# Altium schematic sheet Control.SchDoc(Control)
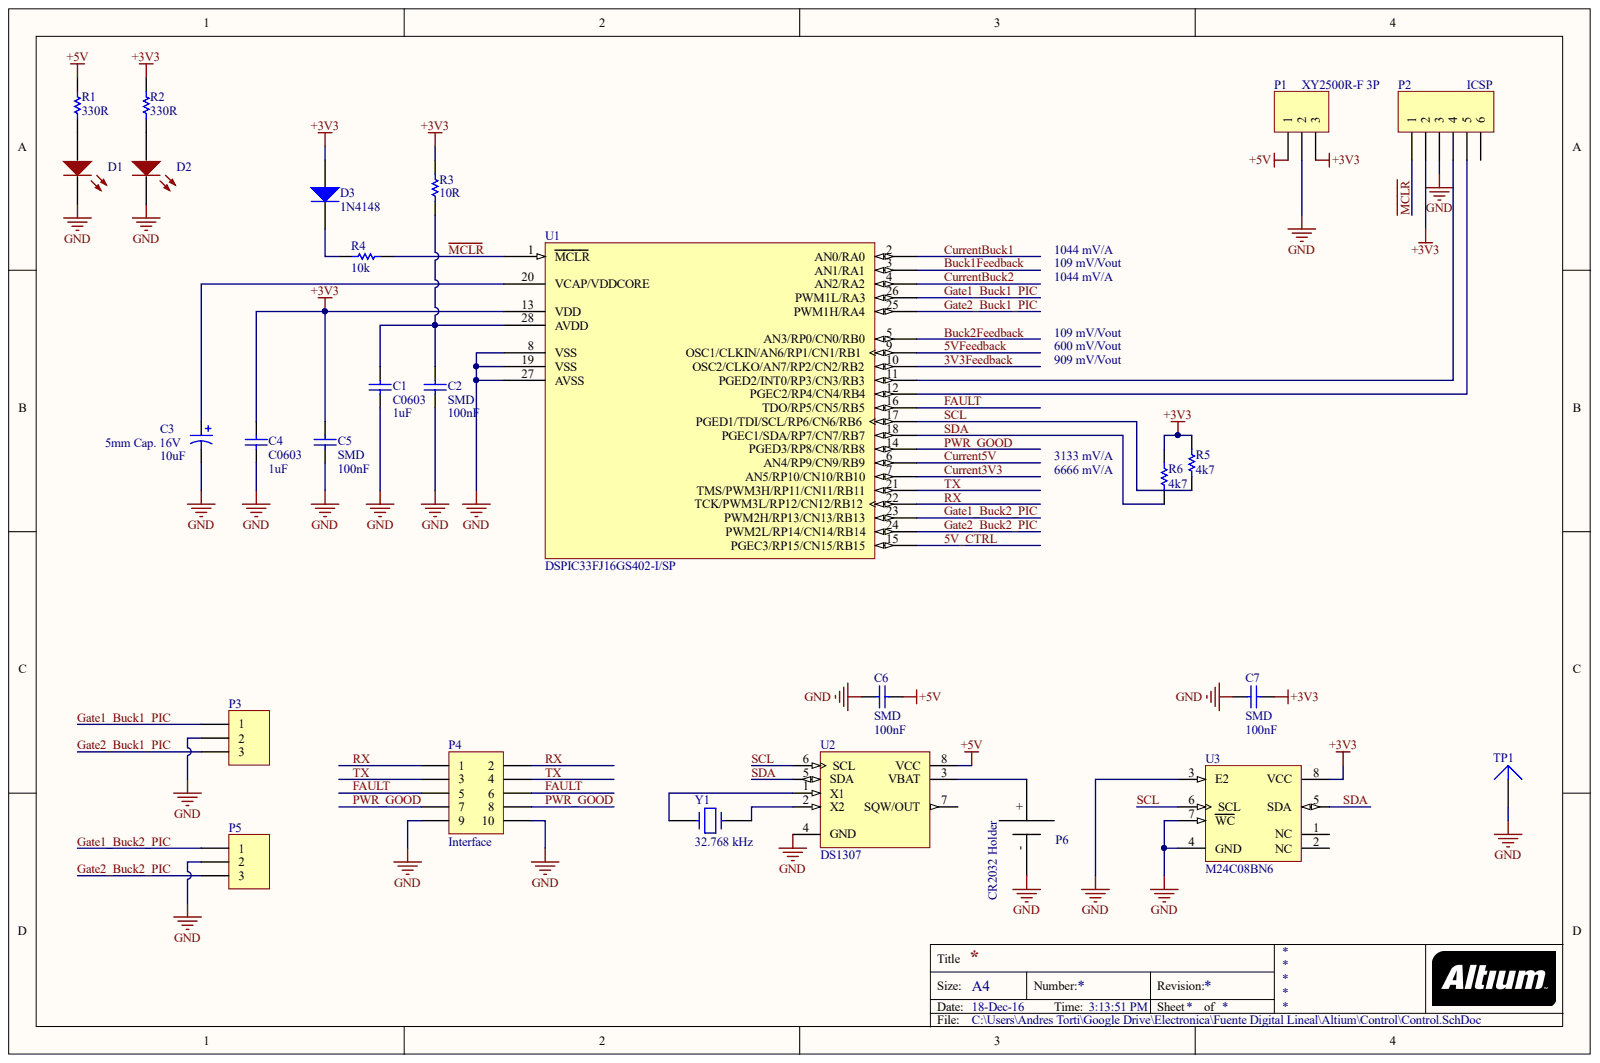
[diagram p0: Control.SchDoc]

## Parts
C1  C0603  value 1uF  [0603]
C2  SMD  value 100nF  [1206]
C3  5mm Cap. 16V  value 10uF  [B]
C4  C0603  value 1uF  [0603]
C5  SMD  value 100nF  [1206]
C6  SMD  value 100nF  [1206]
C7  SMD  value 100nF  [1206]
D1  15-21SURC/S530-A3/TR8  [15-21SURC/S530-A3/TR8]  (value not drawn)
D2  15-21SURC/S530-A3/TR8  [15-21SURC/S530-A3/TR8]  (value not drawn)
D3  1N4148  [SOD80C]
P1  XY2500R-F 3P  [XY2500R-F 3P]
P2  ICSP  [HDR1X6]
P3  Header 3  [My_1X03]  (value not drawn)
P4  Interface  [HDR2X5]
P5  Header 3  [My_1X03]  (value not drawn)
P6  CR2032 Holder  [Porta Pila Boton 3V]
R1  value 330R  [8RB-1206]  (hidden comment: SMD)
R2  value 330R  [8RB-1206]  (hidden comment: SMD)
R3  value 10R  [8RB-1206]  (hidden comment: SMD 1206)
R4  value 10k  [8RB-1206]  (hidden comment: SMD 1206)
R5  value 4k7  [8RB-1206]  (hidden comment: SMD 1206)
R6  value 4k7  [8RB-1206]  (hidden comment: SMD 1206)
TP1  (no value)  [PIN1]
U1  DSPIC33FJ16GS402-I/SP  [SPDIP300-SP28]
U2  DS1307  [DIP8]
U3  M24C08BN6  [PSDIP8A]
Y1  32.768 kHz  [MC306-327680K-A0]

## Nets
+3V3: C4.2 C5.2 C7.2 D3.1 P1.3 P2.2 R2.1 R3.2 R5.1 R6.1 U1.13(VDD) U3.8(VCC)
+5V: C6.2 P1.1 R1.1 U2.8(VCC)
GND: C1.1 C2.1 C3.2 C4.1 C5.1 C6.1 C7.1 D1.2 D2.2 P1.2 P2.3 P3.2 P4.9 P4.10 P5.2 P6.- TP1.1 U1.8(VSS) U1.19(VSS) U1.27(AVSS) U2.4(GND) U3.3(E2) U3.4(GND) U3.7(WC)
3V3FEEDBACK: U1.10(OSC2/CLKO/AN7/RP2/CN2/RB2)
5V_CTRL: U1.15(PGEC3/RP15/CN15/RB15)
5VFEEDBACK: U1.9(OSC1/CLKIN/AN6/RP1/CN1/RB1)
BUCK1FEEDBACK: U1.3(AN1/RA1)
BUCK2FEEDBACK: U1.5(AN3/RP0/CN0/RB0)
CURRENT3V3: U1.7(AN5/RP10/CN10/RB10)
CURRENT5V: U1.6(AN4/RP9/CN9/RB9)
CURRENTBUCK1: U1.2(AN0/RA0)
CURRENTBUCK2: U1.4(AN2/RA2)
FAULT: P4.5 P4.6 U1.16(TDO/RP5/CN5/RB5)
GATE1_BUCK1_PIC: P3.1 U1.26(PWM1L/RA3)
GATE1_BUCK2_PIC: P5.1 U1.23(PWM2H/RP13/CN13/RB13)
GATE2_BUCK1_PIC: P3.3 U1.25(PWM1H/RA4)
GATE2_BUCK2_PIC: P5.3 U1.24(PWM2L/RP14/CN14/RB14)
~{MCLR}: P2.1 R4.2 U1.1(MCLR)
PWR_GOOD: P4.7 P4.8 U1.14(PGED3/RP8/CN8/RB8)
RX: P4.1 P4.2 U1.22(TCK/PWM3L/RP12/CN12/RB12)
SCL: R5.2 U1.17(PGED1/TDI/SCL/RP6/CN6/RB6) U2.6(SCL) U3.6(SCL)
SDA: R6.2 U1.18(PGEC1/SDA/RP7/CN7/RB7) U2.5(SDA) U3.5(SDA)
TX: P4.3 P4.4 U1.21(TMS/PWM3H/RP11/CN11/RB11)
NetC1_2: C1.2 C2.2 R3.1 U1.28(AVDD)
NetC3_1: C3.1 U1.20(VCAP/VDDCORE)
NetD1_1: D1.1 R1.2
NetD2_1: D2.1 R2.2
NetD3_2: D3.2 R4.1
NetP2_4: P2.4 U1.11(PGED2/INT0/RP3/CN3/RB3)
NetP2_5: P2.5 U1.12(PGEC2/RP4/CN4/RB4)
NetP6_+: P6.+ U2.3(VBAT)
NetU2_1: U2.1(X1) Y1.1
NetU2_2: U2.2(X2) Y1.2

Not connected: P2 6; U2 7(SQW/OUT); U3 1(NC) 2(NC)

## Library data of the parts
C1 C4 = C0603: C1608X7R1C105K X7R; Note: Footprint corresponds to dimensions for bulk cassette packaging. This case size has tightened tolerances.; Code_IPC: 1608 [0603]; Code_JEITA: 0603; Component Kind: Standard; ComponentLink1Description: Manufacturer Link; ComponentLink1URL: http://www.kemet.com/; ComponentLink2Description: Datasheet; ComponentLink2URL: http://www.kemet.com/kemet/web/homepage/kechome.nsf/vapubfilesname/F3102TA.pdf/$file/F3102TA.pdf; DatasheetDocument: Mar-2001; LatestRevisionDate: 19-Mar-2004; LatestRevisionNote: Silkscreen size reduced.; Library Name: KEMET Chip Capacitor.IntLib; PackageDocument: Mar-2001; PackageReference: 0603; Published: 17-Jul-2002; Signal Integrity: C0603; Simulation: CAP; Publisher: Altium Limited
C2 C5-C7 = C1206: Ceramic Chip Capacitor - Standard; Code_IPC: 3216 [1206]; Code_JEITA: 1206; Component Kind: Standard; ComponentLink1Description: Manufacturer Link; ComponentLink1URL: http://www.kemet.com/; ComponentLink2Description: Datasheet; ComponentLink2URL: http://www.kemet.com/kemet/web/homepage/kechome.nsf/vapubfilesname/F3102TA.pdf/$file/F3102TA.pdf; DatasheetDocument: Mar-2001; LatestRevisionDate: 19-Mar-2004; LatestRevisionNote: Silkscreen size reduced.; Library Name: KEMET Chip Capacitor.IntLib; PackageDocument: Mar-2001; PackageReference: 1206; Published: 17-Jul-2002; Signal Integrity: C1206; Simulation: CAP; Publisher: Altium Limited
C3 = 94SC106X0010BBP: Solid Aluminum Capacitor with Organic Semiconductor Electrolyte: 10uF, 10V, Bulk Pack; DrillSize: Nominal, Rounded to the nearest 0.1mm; Note: Part Numbers shown are for ± 20% capacitance tolerance (X0).; PCB_Layout: Complies with Manufacturer's Recommendation.; Component Kind: Standard; ComponentLink1Description: Manufacturer Link; ComponentLink1URL: http://www.vishay.com/; ComponentLink2Description: Datasheet; ComponentLink2URL: http://www.vishay.com/docs/90004/94sc.pdf; DatasheetDocument: 23-May-2001; Library Name: Vishay Electrolytic Radial-Lead Capacitor.IntLib; PackageReference: B; Published: 19-Mar-2004; Signal Integrity: 94SC106X0010BBP; Simulation: CAP; Publisher: Altium Limited
D1 D2 = 15-21SURC/S530-A3/TR8 led smd 0805 red: Component Kind: Standard; Description: 15-21SURC/S530-A3/TR8 led smd 0805 red; Library Name: NXT.IntLib
D3 = 1N4148: High Conductance Fast Diode; Code_JEDEC: DO-204-AH; Component Kind: Standard; ComponentLink1Description: Manufacturer Link; ComponentLink1URL: http://www.fairchildsemi.com/; ComponentLink2Description: Datasheet; ComponentLink2URL: http://www.fairchildsemi.com/ds/1N%2F1N4148.pdf; DatasheetDocument: 1997; LatestRevisionDate: 17-Jul-2002; LatestRevisionNote: Re-released for DXP Platform.; Library Name: FSC Discrete Diode.IntLib; PackageReference: DO-35; Published: 8-Jun-2000; Signal Integrity: 1N4148; Simulation: 1N4148; Publisher: Altium Limited
P1 = XY2500R-F 3P: HEADER 3P P/CI 90gra VERDE P=3.81MM XY2500R-F; Component Kind: Standard; Library Name: NXT - Connectors.IntLib
P2 = Header 6: Header, 6-Pin; Component Kind: Standard; LatestRevisionDate: 17-Jul-2002; LatestRevisionNote: Re-released for DXP Platform.; Library Name: Miscellaneous Connectors.IntLib; Signal Integrity: Connector; Publisher: Altium Limited
P3 P5 = Header 3: Header, 3-Pin; Component Kind: Standard; LatestRevisionDate: 17-Jul-2002; LatestRevisionNote: Re-released for DXP Platform.; Library Name: Mylib.IntLib; Signal Integrity: Connector; Publisher: Altium Limited
P4 = Header 5X2: Header, 5-Pin, Dual row; Component Kind: Standard; LatestRevisionDate: 17-Jul-2002; LatestRevisionNote: Re-released for DXP Platform.; Library Name: Miscellaneous Connectors.IntLib; Signal Integrity: Connector; Publisher: Altium Limited
P6 = Porta pila 25mm: Component Kind: Standard; Library Name: My lib 2.IntLib
R1-R6 = ERJ8BQ: Low Ohmic Value Thick Film Chip Resistor, 0.22 Ohm to 9.1 Ohm Range, 1%, 2% and 5% Tolerance, 1206 Size, 0.33 W; Code_IPC: 3216 [1206]; Component Kind: Standard; ComponentLink1Description: Manufacturer Link; ComponentLink1URL: http://www.panasonic.com/industrial/components/resistive_products.htm; ComponentLink2Description: Datasheet; ComponentLink2URL: http://www.panasonic.com/industrial/components/pdf/AOA0000CE3.pdf; LatestRevisionDate: 19-Mar-2004; LatestRevisionNote: Silkscreen size reduced.; Library Name: Panasonic Resistor.IntLib; PackageDocument: Mar-2003; PackageReference: 8RB-1206; Published: 17-Dec-2003; Signal Integrity: Res; Simulation: RESISTOR; Publisher: Altium Limited
TP1 = Plug: Component Kind: Standard; Description: Plug; LatestRevisionDate: 17-Jul-2002; LatestRevisionNote: Re-released for DXP Platform.; Library Name: Miscellaneous Connectors.IntLib; Signal Integrity: Connector; Publisher: Altium Limited
U1 = DSPIC33FJ16GS402-I/SP: High-Performance 16-bit Digital Signal Controller, 16 KB Flash, 2 KB RAM , 28-Pin SPDIP, Industrial Temperature; Component Kind: Standard; ComponentLink1Description: Datasheet; ComponentLink1URL: http://ww1.microchip.com/downloads/en/DeviceDoc/70318D.pdf; ComponentLink2Description: Packaging Specification; ComponentLink2URL: http://www.microchip.com/stellent/groups/techpub_sg/documents/packagingspec/en012702.pdf; ComponentLink3Description: Microchip.com Home; ComponentLink3URL: http://www.microchip.com/; DatasheetVersion: revD, Mar-2009; Library Name: Microchip DSC 16-Bit dsPIC33F.IntLib; PackageDescription: 28-Lead Skinny Plastic Dual In-Line (SP) - 300 mil Body [SPDIP]; PackageReference: SPDIP300-SP28; PackageVersion: revBB, Aug-2009; Published: 2-Dec-2009; Supplier 1: Digi-Key; Supplier 2: Farnell; Supplier Part Number 1: DSPIC33FJ16GS402-I/SP-ND; Supplier Part Number 2: 1778684; Publisher: Altium Limited
U2 = DS1307: 64 X 8 Serial Real-Time Clock; Component Kind: Standard; ComponentLink1Description: Manufacturer Link; ComponentLink1URL: http://www.maxim-ic.com/; ComponentLink2Description: Datasheet; ComponentLink2URL: http://pdfserv.maxim-ic.com/en/ds/DS1307.pdf; ComponentLink3Description: Discontinuation Notice; ComponentLink3URL: http://www.maxim-ic.com/qa/pdfs/non-obsolescence-letter.pdf; DatasheetVersion: 10-Aug-1999; LatestRevisionDate: 17-Jul-2002; LatestRevisionNote: Re-released for DXP Platform.; Library Name: Dallas Peripheral Real Time Clock.IntLib; PackageDescription: DIP, 8-Pin, Row Spacing 7.62 mm, Pitch 2.54 mm; PackageReference: DIP8; PackageVersion: 1999; Published: 13-Oct-2000; Publisher: Altium Limited
U3 = M24C08BN6: 8Kbit Serial I²C Bus EEPROM; Component Kind: Standard; ComponentLink1Description: Manufacturer Link; ComponentLink1URL: http://www.st.com/; ComponentLink2Description: Datasheet; ComponentLink2URL: http://www.st.com/stonline/products/literature/ds/5067/m24c01-r.pdf; DatasheetVersion: Sep-1999; LatestRevisionDate: 06-Jun-2005; LatestRevisionNote: Stylized 3D Model Added.; Library Name: ST Memory EEPROM Serial.IntLib; PackageDescription: DIP; 8 Leads; Row Spacing 7.62 mm; Pitch 2.54 mm; PackageReference: PSDIP8A; PackageVersion: Jun-1998; PCB3D: PSDIP8A; Published: 24-Aug-2001; Publisher: Altium Limited
Y1 = MC306-327680K-A0: MC306-327680K-A0 - XTAL 32.768 KHZ 12.5pF+-20PPM -20+70ºC SMD; Component Kind: Standard; Library Name: NXT - IC.IntLib
